# Altium schematic sheet RoBeast_Positional_Feedback.SchDoc(RoBeast_Positional_Feedback)
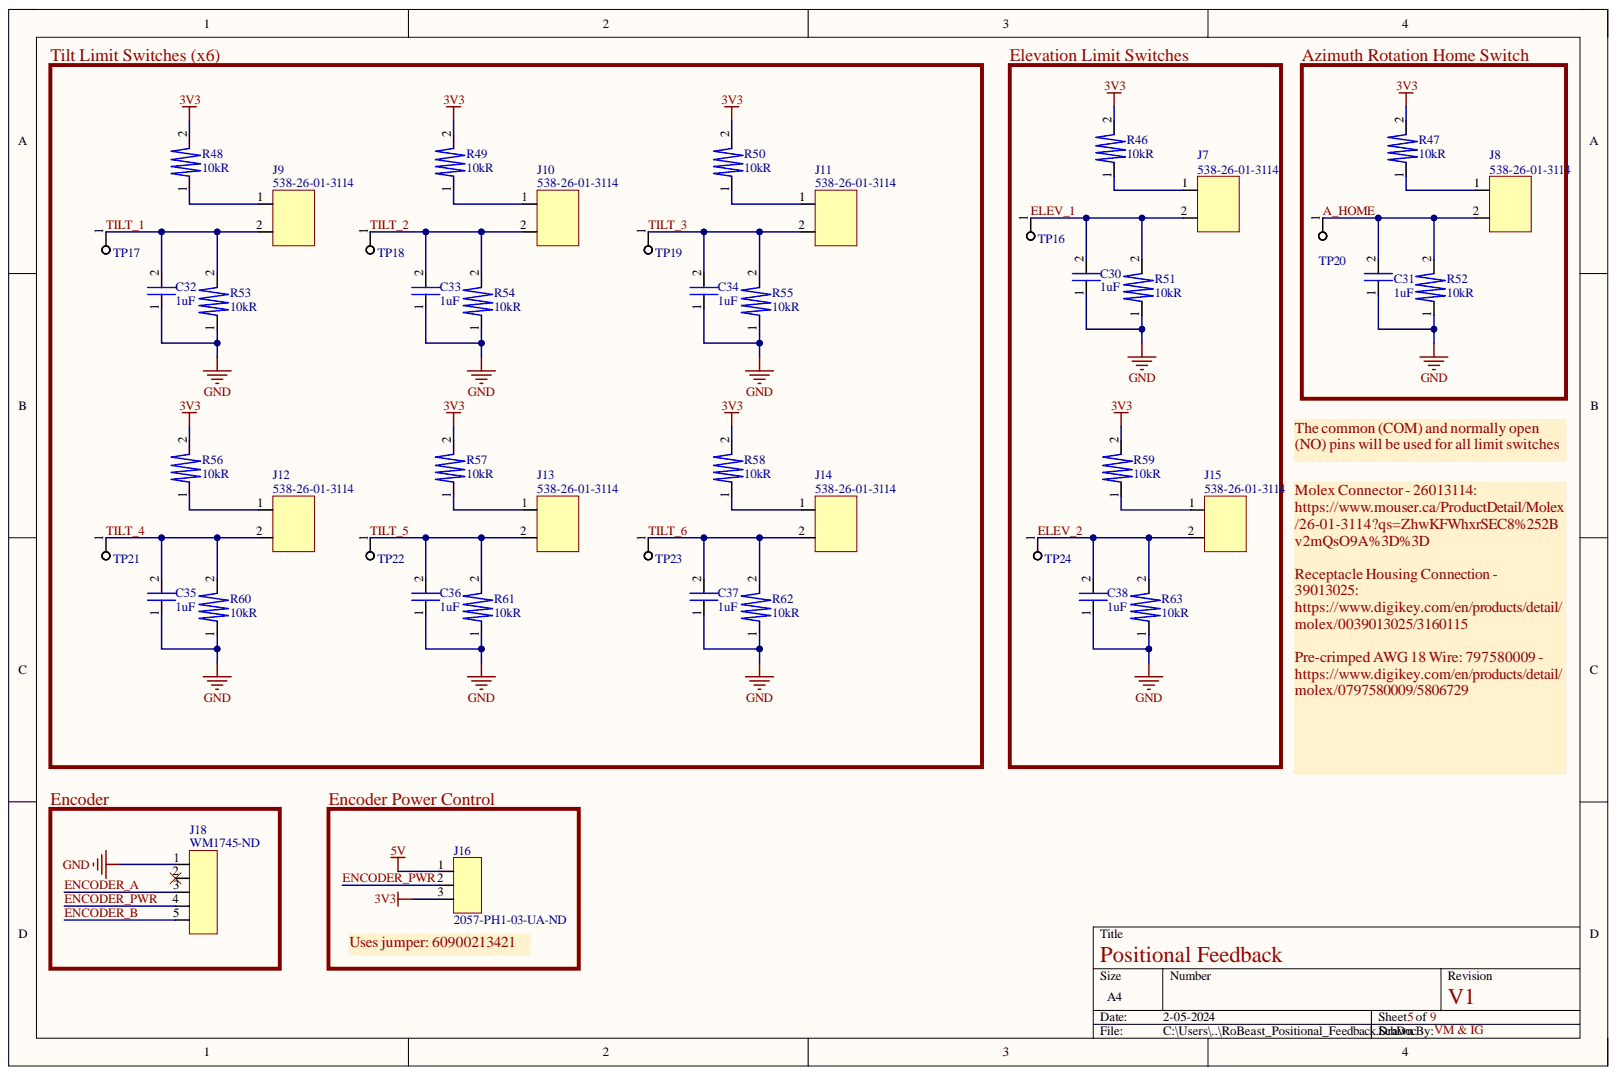
[diagram p0: RoBeast_Positional_Feedback.SchDoc]

## Parts
C30  1uF  [0805]
C31  1uF  [0805]
C32  1uF  [0805]
C33  1uF  [0805]
C34  1uF  [0805]
C35  1uF  [0805]
C36  1uF  [0805]
C37  1uF  [0805]
C38  1uF  [0805]
J7  Supplier Part Number 1: 538-26-01-3114  [26-01-3114]  (hidden comment: 2 Pin Connector)
J8  Supplier Part Number 1: 538-26-01-3114  [26-01-3114]  (hidden comment: 2 Pin Connector)
J9  Supplier Part Number 1: 538-26-01-3114  [26-01-3114]  (hidden comment: 2 Pin Connector)
J10  Supplier Part Number 1: 538-26-01-3114  [26-01-3114]  (hidden comment: 2 Pin Connector)
J11  Supplier Part Number 1: 538-26-01-3114  [26-01-3114]  (hidden comment: 2 Pin Connector)
J12  Supplier Part Number 1: 538-26-01-3114  [26-01-3114]  (hidden comment: 2 Pin Connector)
J13  Supplier Part Number 1: 538-26-01-3114  [26-01-3114]  (hidden comment: 2 Pin Connector)
J14  Supplier Part Number 1: 538-26-01-3114  [26-01-3114]  (hidden comment: 2 Pin Connector)
J15  Supplier Part Number 1: 538-26-01-3114  [26-01-3114]  (hidden comment: 2 Pin Connector)
J16  Supplier Part Number 1: 2057-PH1-03-UA-ND  [PH1-03-UA]  (hidden comment: 3 Pin Connector)
J18  Supplier Part Number: WM1745-ND  [0530480510]  (hidden comment: 5 Pin Male Connector)
R46  10kR  [0805]
R47  10kR  [0805]
R48  10kR  [0805]
R49  10kR  [0805]
R50  10kR  [0805]
R51  10kR  [0805]
R52  10kR  [0805]
R53  10kR  [0805]
R54  10kR  [0805]
R55  10kR  [0805]
R56  10kR  [0805]
R57  10kR  [0805]
R58  10kR  [0805]
R59  10kR  [0805]
R60  10kR  [0805]
R61  10kR  [0805]
R62  10kR  [0805]
R63  10kR  [0805]
TP16  Test Point  [RCWCTE]  (value not drawn)
TP17  Test Point  [RCWCTE]  (value not drawn)
TP18  Test Point  [RCWCTE]  (value not drawn)
TP19  Test Point  [RCWCTE]  (value not drawn)
TP20  Test Point  [RCWCTE]  (value not drawn)
TP21  Test Point  [RCWCTE]  (value not drawn)
TP22  Test Point  [RCWCTE]  (value not drawn)
TP23  Test Point  [RCWCTE]  (value not drawn)
TP24  Test Point  [RCWCTE]  (value not drawn)

## Nets
3V3: J16.3 R46.2 R47.2 R48.2 R49.2 R50.2 R56.2 R57.2 R58.2 R59.2
5V: J16.1
GND: C30.1 C31.1 C32.1 C33.1 C34.1 C35.1 C36.1 C37.1 C38.1 J18.1 R51.1 R52.1 R53.1 R54.1 R55.1 R60.1 R61.1 R62.1 R63.1
A_HOME: C31.2 J8.2 R52.2 TP20.1
ELEV_1: C30.2 J7.2 R51.2 TP16.1
ELEV_2: C38.2 J15.2 R63.2 TP24.1
ENCODER_A: J18.3
ENCODER_B: J18.5
ENCODER_PWR: J16.2 J18.4
TILT_1: C32.2 J9.2 R53.2 TP17.1
TILT_2: C33.2 J10.2 R54.2 TP18.1
TILT_3: C34.2 J11.2 R55.2 TP19.1
TILT_4: C35.2 J12.2 R60.2 TP21.1
TILT_5: C36.2 J13.2 R61.2 TP22.1
TILT_6: C37.2 J14.2 R62.2 TP23.1
NetJ7_1: J7.1 R46.1
NetJ8_1: J8.1 R47.1
NetJ9_1: J9.1 R48.1
NetJ10_1: J10.1 R49.1
NetJ11_1: J11.1 R50.1
NetJ12_1: J12.1 R56.1
NetJ13_1: J13.1 R57.1
NetJ14_1: J14.1 R58.1
NetJ15_1: J15.1 R59.1

Not connected: J18 2

## Library data of the parts
C30-C38 = CC0805JKX7R9BB105: Ceramic Capacitor, Multilayer, Ceramic, 50V, 5% +Tol, 5% -Tol, X7R, 15% TC, 1uF, Surface Mount, 0805; Manufacturer: Yageo; Category: Ceramic Capacitors; Component Kind: Standard; ComponentLink1Description: https://datasheet.ciiva.com:443/pdfs/VipMasterIC/IC/YAGO/YAGO-S-A0003557223/YAGO-S-A0003557223-1.pdf?src-supplier=IHS+Markit; ComponentLink1URL: https://datasheet.ciiva.com:443/pdfs/VipMasterIC/IC/YAGO/YAGO-S-A0003557223/YAGO-S-A0003557223-1.pdf?src-supplier=IHS+Markit; ComponentLink2Description: http://www.yageo.com/documents/recent/UPY-GPHC_X7R_6.3V-to-50V_18.pdf?src-supplier=Digikey; ComponentLink2URL: http://www.yageo.com/documents/recent/UPY-GPHC_X7R_6.3V-to-50V_18.pdf?src-supplier=Digikey; Manufacturer Part Number: CC0805JKX7R9BB105; Pricing 1: 1=0.32, 10=0.207, 50=0.1454, 100=0.1174, 500=0.08724, 1000=0.07633 (USD); RoHS: Yes; Stock 1: 50247; Supplier 1: Digikey; Supplier Part Number 1: 311-3498-1-ND; Library Name: RoBeast_Schematic_Library.SchLib
J7-J15 = 26-01-3114: Rectangular Power Connector, 2 Contact(s), Female, Solder Terminal, Receptacle; Manufacturer: Molex; Board Mounting Option: PEG; Body Depth: 0.764inch; Body Length: 0.21inch; Connector Type: Power,Rectangular; Contact Resistance: 10mR; Contact Style: Hybrid; Dielectric Withstanding Voltage (AC): 1.5kV; Durability: 30Cycles; Insulation Resistance: 1GR; Mixed Contacts: Yes; Mounting Option 1: LOCKING; Number Of Connectors: 1; Number of Contacts: 2; Plating Thickness: 15uin; Rated Current (Signal): 13A; Reference Standard: UL; Reliability: COMMERCIAL; Throw Configuration: S0P2; Body/Shell Style: RECEPTACLE; Category: Headers and Wire Housings; Component Kind: Standard; ComponentLink1Description: https://datasheet.ciiva.com:443/pdfs/VipMasterIC/IC/MOLE/MOLE-S-A0003537933/MOLE-S-A0003536151-1.pdf?src-supplier=IHS+Markit; ComponentLink1URL: https://datasheet.ciiva.com:443/pdfs/VipMasterIC/IC/MOLE/MOLE-S-A0003537933/MOLE-S-A0003536151-1.pdf?src-supplier=IHS+Markit; ComponentLink2Description: http://components.arrow.com/part/dataview/57514732S463087N3303?src-supplier=Arrow+Electronics; ComponentLink2URL: http://components.arrow.com/part/dataview/57514732S463087N3303?src-supplier=Arrow+Electronics; ComponentLink3Description: http://www.farnell.com/datasheets/2788666.pdf?src-supplier=Farnell; ComponentLink3URL: http://www.farnell.com/datasheets/2788666.pdf?src-supplier=Farnell; ComponentLink4Description: http://www.molex.com/customer.html?supplierPN=0026013114&src-supplier=Mouser; ComponentLink4URL: http://www.molex.com/customer.html?supplierPN=0026013114&src-supplier=Mouser; ComponentLink5Description: http://www.farnell.com/datasheets/2788666.pdf?src-supplier=Newark; ComponentLink5URL: http://www.farnell.com/datasheets/2788666.pdf?src-supplier=Newark; ComponentLink6Description: http://www.farnell.com/datasheets/2788666.pdf?src-supplier=Element14; ComponentLink6URL: http://www.farnell.com/datasheets/2788666.pdf?src-supplier=Element14; Contact Finish - Mating: AU ON NI; Contact Finish - Termination: TIN OVER NICKEL; Contact Gender: Female; Contact Material: Brass; Insulation Color: Natural; Insulation Material: Nylon; JESD-609 Code: e3; Manufacturer Part Number: 26-01-3114; Max Operating Temperature: 105°C; Min Operating Temperature: -40°C; Mount: Board; Mounting Style: RIGHT ANGLE; Pricing 1: 1=1.12, 10=0.793, 50=0.793, 100=0.704, 1000=0.635, 10000=0.528 (USD); Reach SVHC Compliant: Yes; RoHS: Yes; RoHS Compliant: Yes; Stock 1: 22788; Supplier 1: Mouser; Terminal Length: 0.14inch; Termination: Solder; Library Name: RoBeast_Schematic_Library.SchLib
J16 = PH1-03-UA: Conn Unshrouded Header HDR 3 POS 2.54mm Solder ST Thru-Hole Bag; Manufacturer: Adam Equipment; Category: Connectors; Component Kind: Standard; ComponentLink1Description: https://datasheet.ciiva.com/16396/catpg240-254-16396026.pdf?src-supplier=Future+Electronics; ComponentLink1URL: https://datasheet.ciiva.com/16396/catpg240-254-16396026.pdf?src-supplier=Future+Electronics; Manufacturer Part Number: PH1-03-UA; Pricing 1: 1=0.1, 10=0.045, 100=0.0285, 500=0.02374, 1000=0.02136, 5000=0.01804, 10000=0.01709 (USD); RoHS: Yes; Stock 1: 50788; Supplier 1: Digikey; Library Name: RoBeast_Schematic_Library.SchLib
J18 = 0530480510: Board Connector, 5 Contact(s), 1 Row(s), Male, Right Angle, 0.049 inch Pitch, Solder Terminal, Locking, Natural Insulator, Receptacle; Manufacturer: Molex; Manufacturer Part Number: 53048-0510; Manufacturer Series: 53048; Body Breadth: 0.138inch; Body Depth: 0.217inch; Body Length: 0.315inch; Contact Pattern: RECTANGULAR; Contact Resistance: 20mR; Contact Style: SQ PIN-SKT; DIN Conformance: No; Insulation Resistance: 100MR; Mating Information: MULTIPLE MATING PARTS AVAILABLE; Number Of Connectors: 1; Number of Contacts: 5; Option: General Purpose; Reliability: COMMERCIAL; Body/Shell Style: RECEPTACLE; Category: Headers and Wire Housings; Component Kind: Standard; ComponentLink1Description: https://datasheet.ciiva.com:443/pdfs/VipMasterIC/IC/MOLE/MOLE-S-A0001318164/MOLE-S-A0001396416-1.pdf?src-supplier=IHS+Markit; ComponentLink1URL: https://datasheet.ciiva.com:443/pdfs/VipMasterIC/IC/MOLE/MOLE-S-A0001318164/MOLE-S-A0001396416-1.pdf?src-supplier=IHS+Markit; Connector Type: BOARD CONNECTOR; Contact Finish - Mating: TIN; Contact Finish - Termination: Tin (Sn); Contact Gender: Male; Contact Material: Bronze; Filter Feature: No; Flammability Rating: UL94 V-0; IEC Conformance: No; Insulation Color: Natural; Insulation Material: Nylon; JESD-609 Code: e3; Mating Contact Pitch: 0.049inch; Max Operating Temperature: 85°C; MIL Conformance: No; Min Operating Temperature: -40°C; Mixed Contacts: No; Mounting Option 1: LOCKING; Mounting Style: RIGHT ANGLE; Mounting Technology: Board; Number Of PCB Rows: 1; Number of Rows Loaded: 1; PCB Contact Pattern: RECTANGULAR; Pricing: 1=0.49, 10=0.414, 80=0.32175, 4000=0.22983, 8000=0.20684, 12000=0.20378 (USD); Reach SVHC Compliant: Yes; RoHS: Yes; RoHS Compliant: Yes; Stock: 61267; Supplier: Digikey; Terminal Length: 0.091inch; Terminal Pitch: 1.25mm; Termination: Solder; Library Name: RoBeast_Schematic_Library.SchLib
R46-R63 = RMCF0805FT10K0: Fixed Resistor, Metal Glaze/thick Film, 0.125W, 10000ohm, 150V, 1% +/-Tol, 100ppm/Cel, Surface Mount, 0805; Manufacturer: Stackpole Electronics; Package Height: 0.5mm; Package Length: 2mm; Package Width: 1.25mm; Rated Power Dissipation (P): 125mW; Rated Temperature: 70°C; Reference Standard: AEC-Q200; Temperature Coefficient: 100ppm/°C; Category: Chip SMD Resistors; Component Kind: Standard; ComponentLink1Description: https://datasheet.ciiva.com:443/pdfs/VipMasterIC/IC/SEIE/SEIE-S-A0009541969/SEIE-S-A0009541969-1.pdf?src-supplier=IHS+Markit; ComponentLink1URL: https://datasheet.ciiva.com:443/pdfs/VipMasterIC/IC/SEIE/SEIE-S-A0009541969/SEIE-S-A0009541969-1.pdf?src-supplier=IHS+Markit; ComponentLink2Description: https://datasheet.ciiva.com/15193/getdatasheetpartid-362046-15193525.pdf?src-supplier=Verical; ComponentLink2URL: https://datasheet.ciiva.com/15193/getdatasheetpartid-362046-15193525.pdf?src-supplier=Verical; Construction: Rectangular; JESD-609 Code: e3; Manufacturer Part Number: RMCF0805FT10K0; Max Operating Temperature: 155°C; Min Operating Temperature: -55°C; Mount: Surface Mount; Number of Pins: 2; Package Shape: Rectangular; Package Style: SMTMeter; Packaging: Tape and Reel; Pricing 1: 1=0.1, 10=0.02, 50=0.0124, 100=0.0103, 500=0.007, 1000=0.00601 (USD); Reach SVHC Compliant: Yes; Resistance: 10kR; Resistor Type: FIXED RESISTOR; RoHS: Yes; RoHS Compliant: Yes; Size Code: 0805; Stock 1: 3602197; Supplier 1: Digikey; Supplier Part Number 1: RMCF0805FT10K0CT-ND; Technology: METAL GLAZE/THICK FILM; Terminal Finish: Nickel; Termination: Wraparound; Tolerance: 1%; Working Voltage: 150V; Library Name: RoBeast_Schematic_Library.SchLib
TP16-TP24 = RCWCTE: Testing/Accessories; Manufacturer: KOA Speer; Package Height: 2mm; Package Length: 3.2mm; Package Width: 1.6mm; Rated Temperature: 70°C; Reference Standard: AEC-Q200; Resistance: 0R; Category: Chip SMD Resistors; Component Kind: Standard; ComponentLink1Description: https://datasheet.ciiva.com:443/pdfs/VipMasterIC/IC/KOAS/KOASS03065/KOASS03065-1.pdf?src-supplier=IHS+Markit; ComponentLink1URL: https://datasheet.ciiva.com:443/pdfs/VipMasterIC/IC/KOAS/KOASS03065/KOASS03065-1.pdf?src-supplier=IHS+Markit; ComponentLink2Description: https://datasheet.ciiva.com/34302/1876186-34302940.pdf?src-supplier=Jameco; ComponentLink2URL: https://datasheet.ciiva.com/34302/1876186-34302940.pdf?src-supplier=Jameco; ComponentLink3Description: https://datasheet.ciiva.com/5556/rc-5556236.pdf?src-supplier=Mouser; ComponentLink3URL: https://datasheet.ciiva.com/5556/rc-5556236.pdf?src-supplier=Mouser; ComponentLink4Description: https://datasheet.ciiva.com/26821/getdatasheetpartid-110197-26821157.pdf?src-supplier=Verical; ComponentLink4URL: https://datasheet.ciiva.com/26821/getdatasheetpartid-110197-26821157.pdf?src-supplier=Verical; Construction: Chip; JESD-609 Code: e2; Manufacturer Part Number: RCWCTE; Max Operating Temperature: 125°C; Min Operating Temperature: -55°C; Mount: Surface Mount; Number of Pins: 2; Package Shape: Rectangular; Package Style: SMTMeter; Packaging: Tape and Reel; Pricing 1: 1=0.16, 10=0.137, 25=0.1212, 50=0.1132, 100=0.105, 250=0.09292, 500=0.08888, 1000=0.07272 (USD); Reach SVHC Compliant: Yes; Resistor Type: FIXED RESISTOR; RoHS: Yes; RoHS Compliant: Yes; Size Code: 1206; Stock 1: 132357; Supplier 1: Digikey; Supplier Part Number 1: 2019-RCWCTECT-ND; Terminal Finish: Copper; Termination: Wraparound; Library Name: RoBeast_Schematic_Library.SchLib
